# Altium schematic sheet 12_PX4_IO.SchDoc(12_PX4_IO)
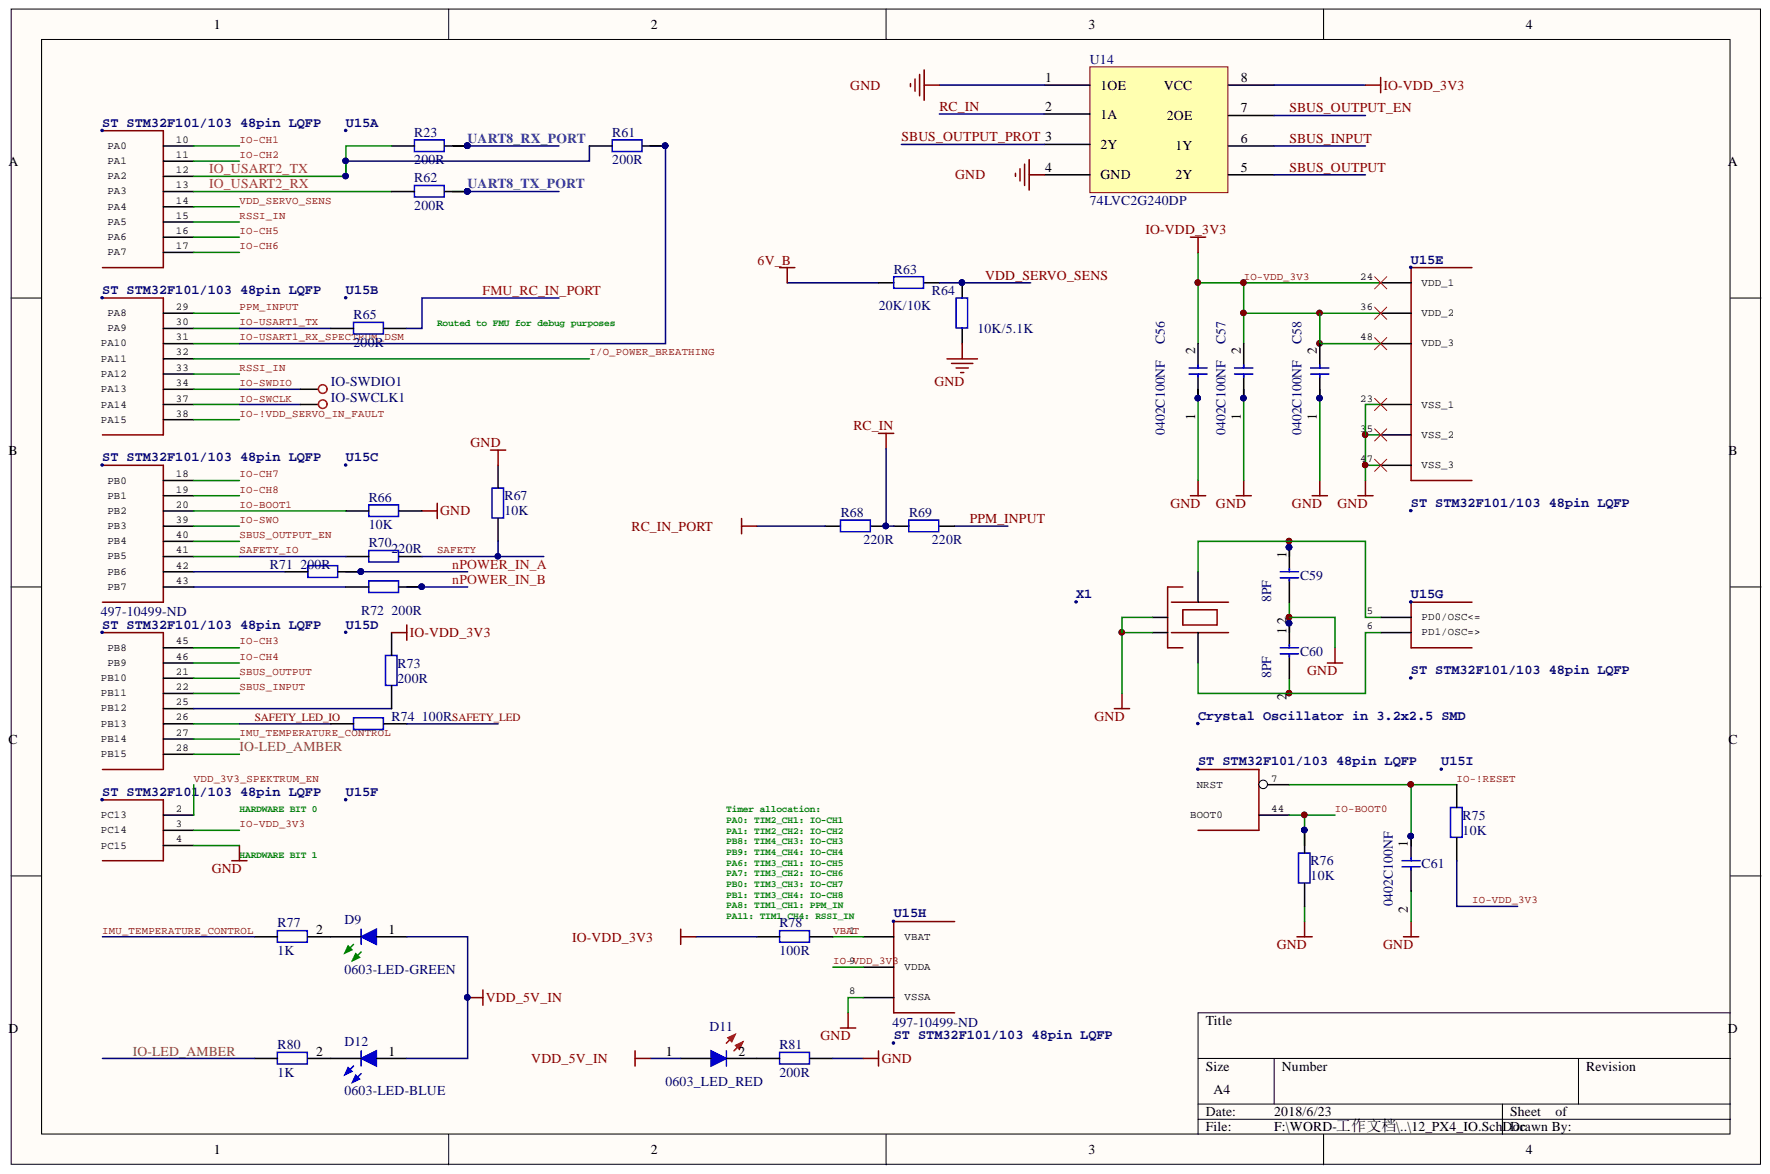
[diagram p0: 12_PX4_IO.SchDoc]

## Parts
C56  0402C100NF  [0402C]
C57  0402C100NF  [0402C]
C58  0402C100NF  [0402C]
C59  8PF  [0402C]
C60  8PF  [0402C]
C61  0402C100NF  [0402C]
D9  0603-LED-GREEN  [0603-LED-Green]
D11  0603_LED_RED  [0603-LED-RED]
D12  0603-LED-BLUE  [0603-LED-blue]
IO-SWCLK1  TP_0.75  [TP0.75]  (value not drawn)
IO-SWDIO1  TP_0.75  [TP0.75]  (value not drawn)
R23  200R  [0402R]
R61  200R  [0402R]
R62  200R  [0402R]
R63  20K/10K  [R0402]
R64  10K/5.1K  [R0402]
R65  200R  [0402R]
R66  10K  [0402R]
R67  10K  [0402R]
R68  220R  [R0402]
R69  220R  [R0402]
R70  220R  [0402R]
R71  200R  [0402R]
R72  200R  [0402R]
R73  200R  [0402R]
R74  100R  [0402R]
R75  10K  [0402R]
R76  10K  [0402R]
R77  1K  [0402R]
R78  100R  [0402R]
R80  1K  [0402R]
R81  200R  [0402R]
U14  74LVC2G240DP  [TSSOP8]
U15  ST STM32F101/103 48pin LQFP  [TQFP48]  (parts A,B,C,D,E,F,G,H,I)
X1  Crystal Oscillator in 3.2x2.5 SMD  [3225]

## Nets
6V_B: R63.1
GND: C56.1 C57.1 C58.1 C59.2 C60.1 C61.2 R64.1 R66.2 R67.2 R76.1 R81.2 U14.1(1OE) U14.4(GND) U15.4 U15.8(VSSA) U15.23(VSS_1) U15.35(VSS_2) U15.47(VSS_3) X1.2 X1.4
RC_IN_PORT: R68.1
VDD_5V_IN: D9.1 D11.1 D12.1
FMU_RC_IN_PORT: R65.2
I/O_POWER_BREATHING: U15.32
IMU_TEMPERATURE_CONTROL: R77.1 U15.27
IO-!RESET: C61.1 R75.1 U15.7
IO-!VDD_SERVO_IN_FAULT: U15.38
IO-BOOT0: R76.2 U15.44
IO-BOOT1: R66.1 U15.20
IO-CH1: U15.10
IO-CH2: U15.11
IO-CH3: U15.45
IO-CH4: U15.46
IO-CH5: U15.16
IO-CH6: U15.17
IO-CH7: U15.18
IO-CH8: U15.19
IO-LED_AMBER: R80.1 U15.28
IO-SWCLK: IO-SWCLK1.0 U15.37
IO-SWDIO: IO-SWDIO1.0 U15.34
IO-SWO: U15.39
IO-USART1_RX_SPECTRUM_DSM: R61.2 U15.31
IO-USART1_TX: R65.1 U15.30
IO-VDD_3V3: C56.2 C57.2 C58.2 R73.2 R75.2 R78.1 U14.8 U15.3 U15.9(VDDA) U15.24(VDD_1) U15.36(VDD_2) U15.48(VDD_3)
IO_USART2_RX: R62.1 U15.13
IO_USART2_TX: R23.1 R61.1 U15.12
NPOWER_IN_A: R71.2
NPOWER_IN_B: R72.2
PPM_INPUT: R69.2 U15.29
RC_IN: R68.2 R69.1 U14.2(1A)
RSSI_IN: U15.15 U15.33
SAFETY: R67.1 R70.1
SAFETY_IO: R70.2 U15.41
SAFETY_LED: R74.1
SAFETY_LED_IO: R74.2 U15.26
SBUS_INPUT: U14.6 U15.22
SBUS_OUTPUT: U14.5 U15.21
SBUS_OUTPUT_EN: U14.7 U15.40
SBUS_OUTPUT_PROT: U14.3(2Y)
UART8_RX_PORT: R23.2
UART8_TX_PORT: R62.2
VBAT: R78.2 U15.1(VBAT)
VDD_3V3_SPEKTRUM_EN: U15.2
VDD_SERVO_SENS: R63.2 R64.2 U15.14
NetC59_1: C59.1 U15.5 X1.3
NetC60_2: C60.2 U15.6 X1.1
NetD9_2: D9.2 R77.2
NetD11_2: D11.2 R81.1
NetD12_2: D12.2 R80.2
NetR71_1: R71.1 U15.42
NetR72_1: R72.1 U15.43
NetR73_1: R73.1 U15.25

## Library data of the parts
C56-C61 = 0402C100NF: 50V 5%; Component Kind: Standard; Library Name: CUAV.SchLib
IO-SWCLK1 IO-SWDIO1 = TP_0.75: TP_0.75MM; Component Kind: Standard; Library Name: CUAV.SchLib
R23 R61 R62 R65-R67 R70-R78 R80 R81 = 0402R1K: 0402R1K 1%; Component Kind: Standard; Library Name: CUAV.SchLib
R63 R64 = Res2: Resistor; Value: 1K; Component Kind: Standard; LatestRevisionDate: 17-Jul-2002; LatestRevisionNote: Re-released for DXP Platform.; Library Name: Miscellaneous Devices.IntLib; PackageDescription: Axial Device, Thru-Hole; 2 Leads; 0.4 in Pin Spacing; PackageReference: AXIAL-0.4; Published: 8-Jun-2000; Signal Integrity: Res; Simulation: RESISTOR; Publisher: Altium Limited
R68 R69 = Res2: Resistor; Component Kind: Standard; Library Name: Miscellaneous Devices.IntLib; Signal Integrity: Res; Simulation: RESISTOR
U14 = 74LVC2G240: Component Kind: Standard; Library Name: hack.SchLib
U15 = STM32F10XCXT6: MCU 32BIT ARM 64K FLASH 48LQFP; Component Kind: Standard
X1 = OSCILLATOR-3.2X2.5: CRYSTAL 24MHZ 8PF SMD; Component Kind: Standard
D9 = 0603-LED-GREEN: Component Kind: Standard; Description: 0603-LED-GREEN; Library Name: CUAV.SchLib
D11 = 0603_LED_RED: Component Kind: Standard; Description: 0603_LED_RED; Library Name: CUAV.SchLib
D12 = 0603-LED-BLUE: Component Kind: Standard; Description: 0603-LED-BLUE; Library Name: CUAV.SchLib
